# Altium schematic sheet 03 电源部分.SchDoc("03 电源部分")
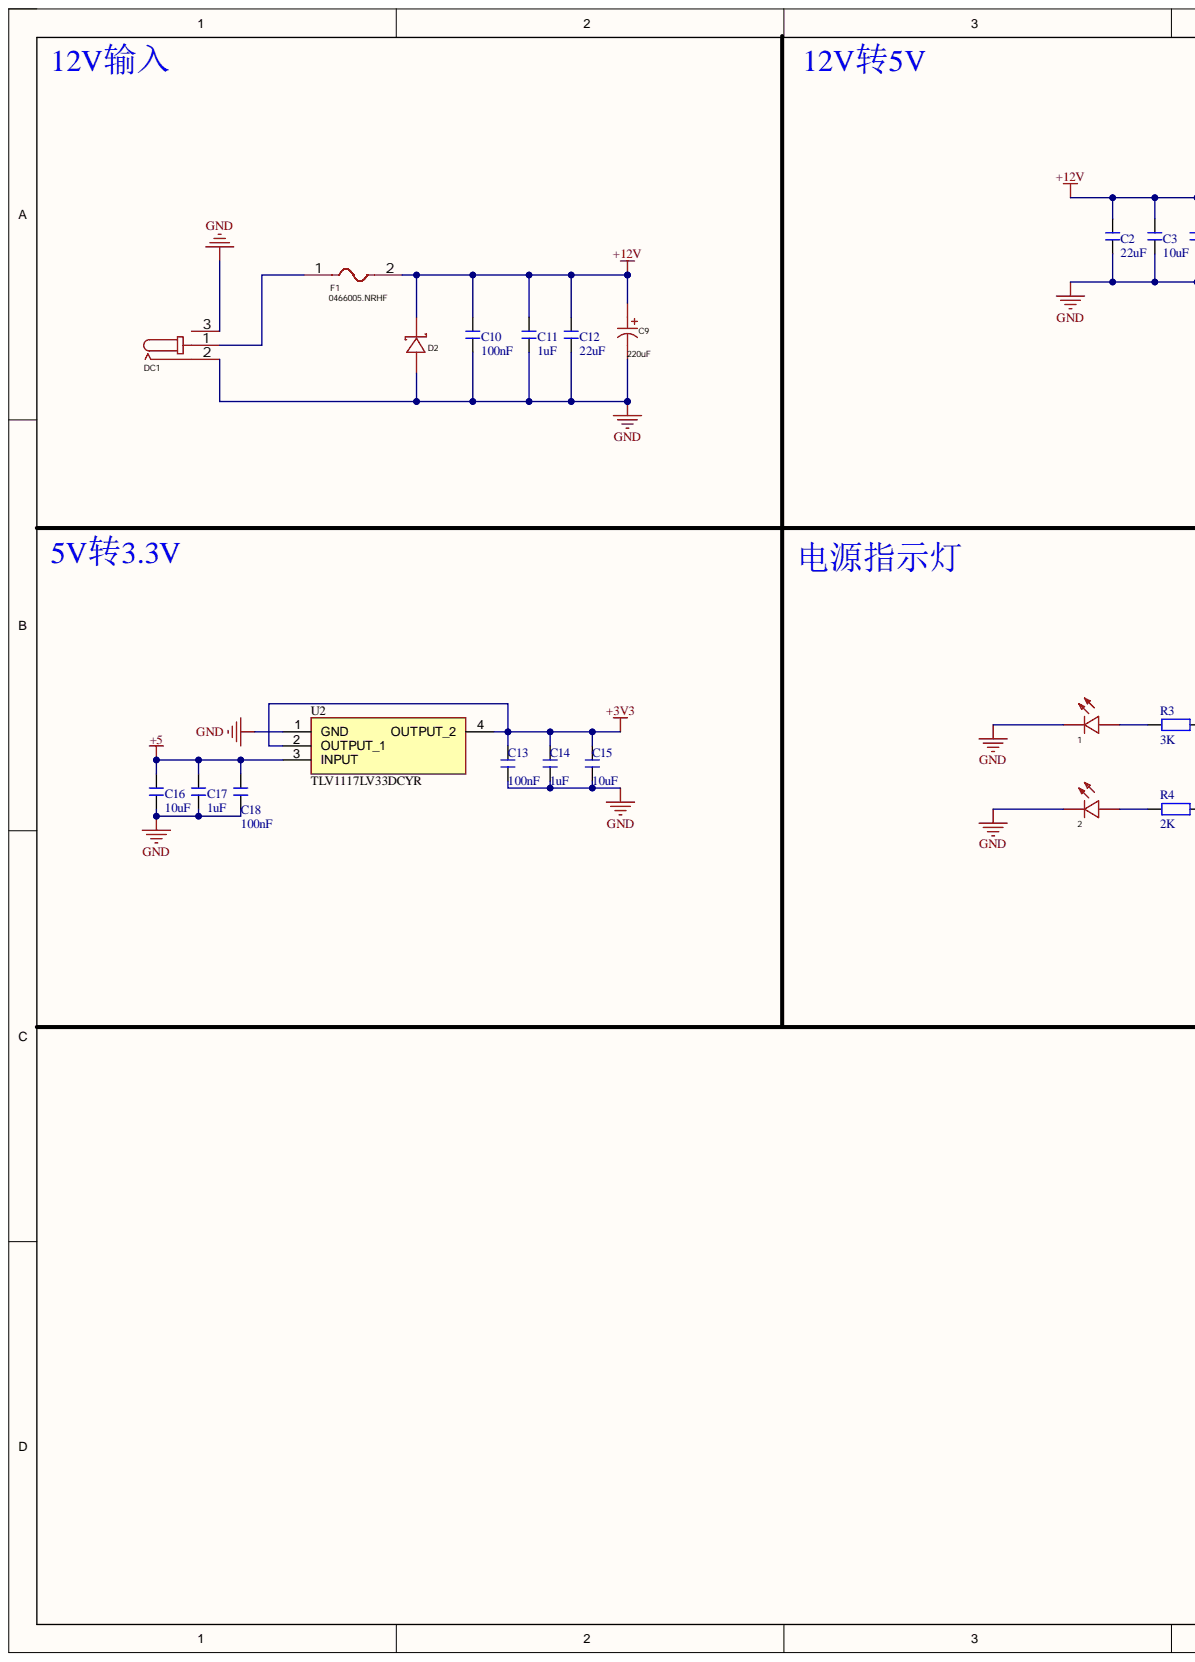
[diagram p0: 03 电源部分.SchDoc, left]
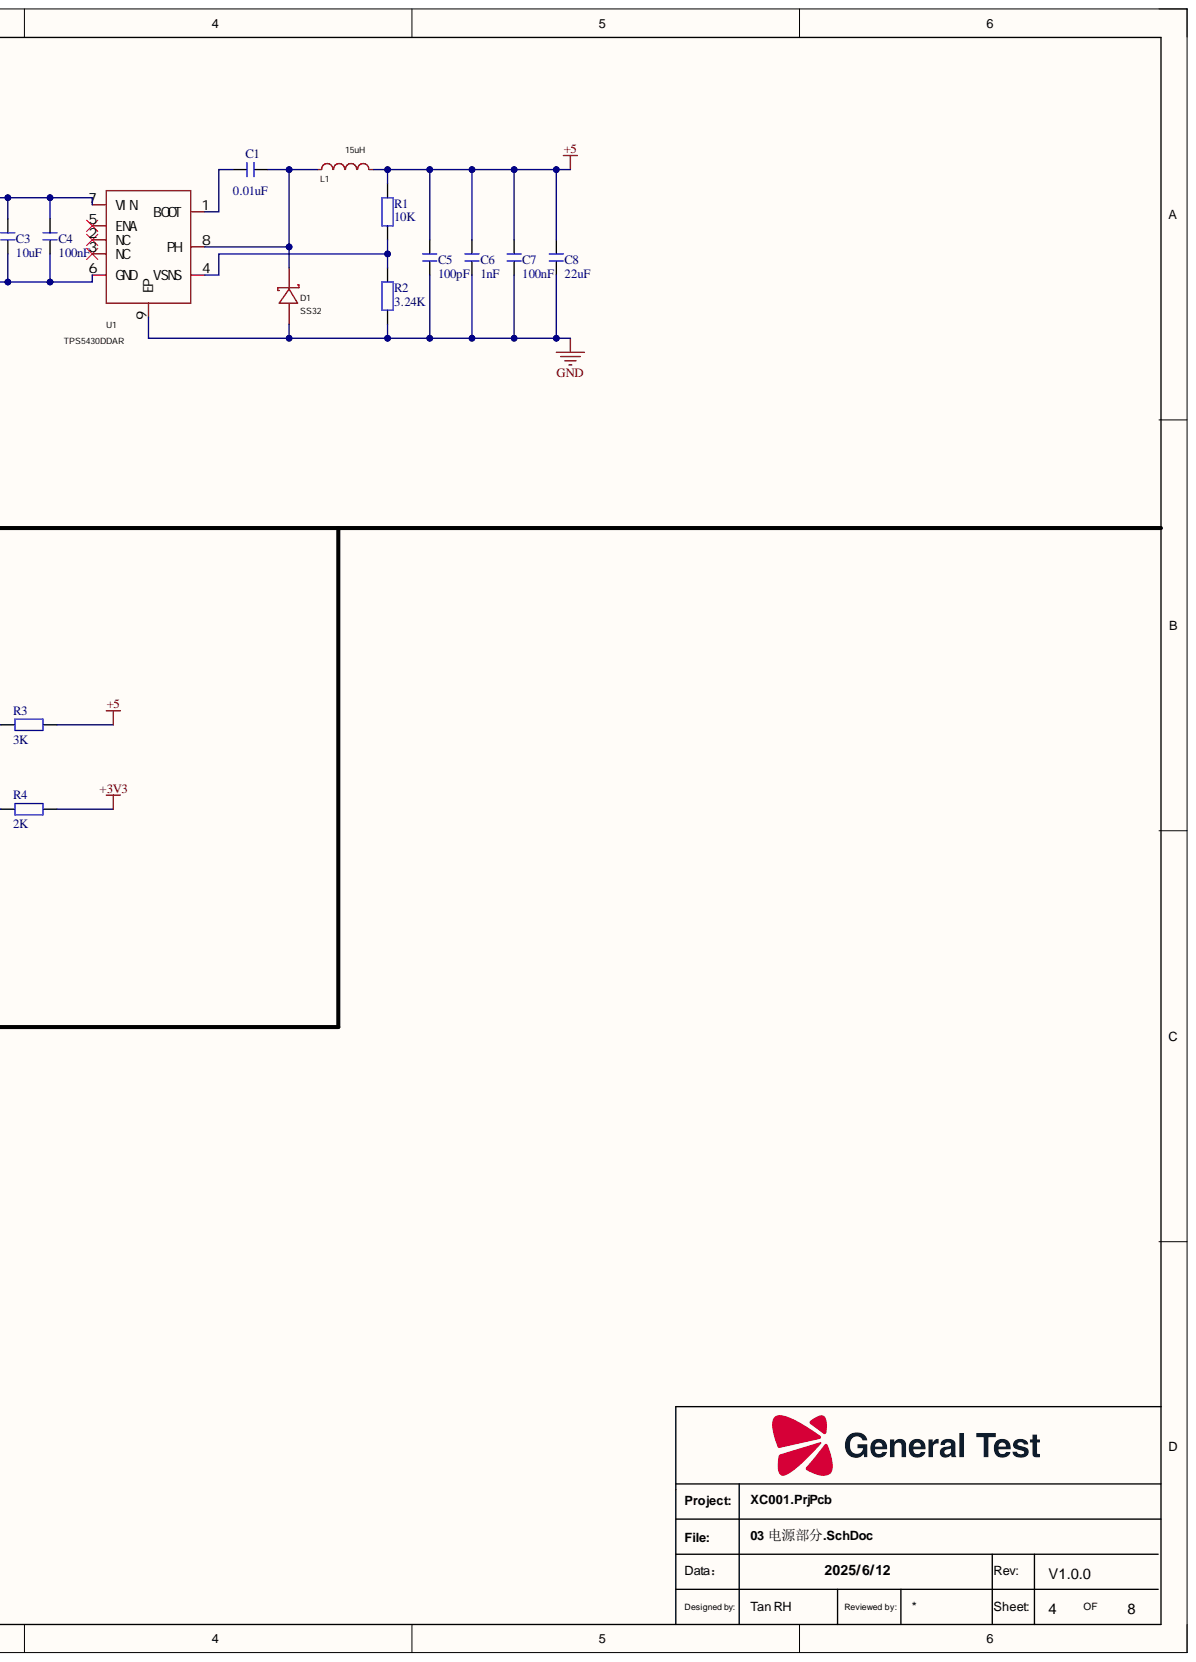
[diagram p1: 03 电源部分.SchDoc, right]

## Parts
1  NCD0603B1  [LED0603-RD]  (value not drawn)
2  NCD0603B1  [LED0603-RD]  (value not drawn)
C1  0.01uF  [C0805_M]
C2  22uF  [C0805_M]
C3  10uF  [C0805_M]
C4  100nF  [C0603_L]
C5  100pF  [C0603_L]
C6  1nF  [C0603_L]
C7  100nF  [C0603_L]
C8  22uF  [C0805_M]
C9  220uF  [CAP-SMD_BD6.3-L6.6-W6.6-LS7.4-FD]
C10  100nF  [C0603_L]
C11  1uF  [C0603_L]
C12  22uF  [C0805_M]
C13  100nF  [C0603_L]
C14  1uF  [C0603_L]
C15  10uF  [C0603_L]
C16  10uF  [C0603_L]
C17  1uF  [C0603_L]
C18  100nF  [C0603_L]
D1  SS32  [SMA_L4.3-W2.6-LS5.2-RD]
D2  SS32  [SMA_L4.3-W2.6-LS5.2-RD]  (value not drawn)
DC1  DC-053D-25A  [DC-IN-TH_DC-053D-25A-1]  (value not drawn)
F1  0466005.NRHF  [F1206]
L1  15uH  [IND-SMD_L7.1-W6.6_SLO0618H]
R1  10K  [R0603_L]
R2  3.24K  [R0603_L]
R3  3K  [R0603_L]
R4  2K  [R0603_L]
U1  TPS5430DDAR  [SOIC127P600X170-9N]
U2  TLV1117LV33DCYR  [SOT230P700X180-4N]

## Nets
+3V3: C13.2 C14.2 C15.2 R4.2 U2.2(OUTPUT_1) U2.4(OUTPUT_2)
+5: C5.2 C6.2 C7.2 C8.2 C16.2 C17.2 C18.2 L1.2 R1.2 R3.2 U2.3(INPUT)
+12V: C2.2 C3.2 C4.2 C9.1 C10.1 C11.1 C12.1 D2.1 F1.2 U1.7(VIN)
GND: 1.1 2.1 C2.1 C3.1 C4.1 C5.1 C6.1 C7.1 C8.1 C9.2 C10.2 C11.2 C12.2 C13.1 C14.1 C15.1 C16.1 C17.1 C18.1 D1.2 D2.2 DC1.2 DC1.3 R2.1 U1.6(GND) U1.9(EP) U2.1(GND)
Net1_2: 1.2 R3.1
Net2_2: 2.2 R4.1
NetC1_1: C1.1 D1.1 L1.1 U1.8(PH)
NetC1_2: C1.2 U1.1(BOOT)
NetDC1_1: DC1.1 F1.1
NetR1_1: R1.1 R2.2 U1.4(VSNS)

Not connected: U1 2(NC) 3(NC) 5(ENA)

## Library data of the parts
1 2 = NCD0603B1: Manufacturer: 国星光电; Add into BOM: yes; Dominant Wavelength: 463nm~475nm; Emitted Color: 蓝色; Forward Current: 10mA; Forward Voltage (VF): 2.6V~3.6V; Lens Color: 无色透明透镜; Luminous Intensity: 80mcd~220mcd; Power Dissipation: 72mW; Symbol: FC-DA1608BK-470H10; Viewing Angle: 130°; Component Kind: Standard; Convert to PCB: yes; Datasheet: https://atta.szlcsc.com/upload/public/pdf/source/20200820/C84266_6A3CDBEFA72C6CAD68EDA53474ADAE6C.pdf; Device: NCD0603B1; JLCPCB Part Class: Extended Part; LCSC Part Name: NCD0603B1; Library Name: Schlib1.SchLib; Manufacturer Part: NCD0603B1; Operating Temperature: -30℃~+85℃; Supplier: LCSC; Supplier Footprint: 0603; Supplier Part: C84266
C1-C8 C10-C12 = C: 贴片电容; BOM名称: 无极性贴片电容; 淘宝ID: 封装库来自淘宝 ID：黄晶宝石; Component Kind: Standard; Library Name: 0.1 - 电阻-电容-电感.SchLib; 唯一出售店铺网址: https://shop463601444.taobao.com; 联系售后，技术支持: https://shop463601444.taobao.com
C9 = RVT1E221M0607: Manufacturer: ROQANG(容强); Add into BOM: yes; L: 7.7mm; Lifetime @ Temp: 2000hrs@105℃; Rated Voltage: 25V; Ripple Current: 150mA@120Hz; Symbol: RVT1E221M0607_C2918361; Tolerance: ±20%; φD: 6.3mm; Component Kind: Standard; Convert to PCB: yes; Datasheet: https://atta.szlcsc.com/upload/public/pdf/source/20211118/497C0614266278696CA52BDF245B991F.pdf; Device: RVT1E221M0607; Equivalent Series Resistance(ESR): -; JLCPCB Part Class: Extended Part; LCSC Part Name: 220uF ±20% 25V; Library Name: RK3562 Pcb.SchLib; Manufacturer Part: RVT1E221M0607; Operating Temperature: -40℃~+105℃; PCB3D: CAP-SMD_BD6.3-L6.6-W6.6-LS7.4-FD; Supplier: LCSC; Supplier Footprint: SMD,D6.3xL7.7mm; Supplier Part: C2918361; Value: 220uF
C13 C18 = C: 贴片电容; BOM名称: 无极性贴片电容; LibRef: GRM155R71C104JA88D; 淘宝ID: 封装库来自淘宝 ID：黄晶宝石; Component Kind: Standard; Library Name: 0.1 - 电阻-电容-电感.SchLib; 唯一出售店铺网址: https://shop463601444.taobao.com; 联系售后，技术支持: https://shop463601444.taobao.com
C14 C17 = C: 贴片电容; BOM名称: 无极性贴片电容; LibRef: GRM155R61H105KE05D; 淘宝ID: 封装库来自淘宝 ID：黄晶宝石; Component Kind: Standard; Library Name: 0.1 - 电阻-电容-电感.SchLib; 唯一出售店铺网址: https://shop463601444.taobao.com; 联系售后，技术支持: https://shop463601444.taobao.com
C15 C16 = C: 贴片电容; BOM名称: 无极性贴片电容; LibRef: GRM155C80J106ME18D; 淘宝ID: 封装库来自淘宝 ID：黄晶宝石; Component Kind: Standard; Library Name: 0.1 - 电阻-电容-电感.SchLib; 唯一出售店铺网址: https://shop463601444.taobao.com; 联系售后，技术支持: https://shop463601444.taobao.com
D1 D2 = SS32_C34897: Manufacturer: MDD(辰达半导体); Add into BOM: yes; Diode Configuration: 独立式; Forward Voltage (Vf@If): 550mV@3A; Rectified Current: 3A; Reverse Leakage Current (Ir): 500uA@20V; Reverse Voltage (Vr): 20V; Component Kind: Standard; Convert to PCB: yes; Datasheet: https://atta.szlcsc.com/upload/public/pdf/source/20240710/2118BB86FDDB2E0B294389AC1F3F65C8.pdf; Device: SS32_C34897; JLCPCB Part Class: Extended Part; LCSC Part Name: 电压:20V 电流:3A; Manufacturer Part: SS32; Supplier: LCSC; Supplier Footprint: SMA(DO-214AC); Supplier Part: C34897; Symbol: SS32_C34897
  D1: Library Name: Schlib1.SchLib
  D2: Library Name: PowerDetector.SchLib
DC1 = DC-053D-25A: Manufacturer: 韩国韩荣; Add into BOM: yes; Connector Type: DC电源插座; Current Rating (Max): 10A; Inside Contact Diameter: 2.5mm; Outside Contact Diameter: 6.5mm; Voltage Rating (Max): 16V; Component Kind: Standard; Convert to PCB: yes; Datasheet: https://atta.szlcsc.com/upload/public/pdf/source/20211013/02DB261FD23242C4DED947007A9F6266.pdf; Device: DC-053D-25A; JLCPCB Part Class: Extended Part; LCSC Part Name: DC电源插座 内径:2.5mm 外径:6.5mm; Library Name: RK3562 Pcb.SchLib; Manufacturer Part: DC-053D-25A; Mounting Style: 弯插; Operating Temperature Range: -20℃~+70℃; PCB3D: DC-IN-TH_DC-053D-25A-1; Supplier: LCSC; Supplier Footprint: 插件; Supplier Part: C2686352; Symbol: DC-053D-25A
F1 = 0466005.NRHF: Manufacturer: Littelfuse(美国力特); Add into BOM: yes; Breaking Capacity: 50A; Current Rating: 5A; Type: 贴片式保险丝; Voltage Rating  (AC): 32V; Component Kind: Standard; Convert to PCB: yes; Datasheet: https://atta.szlcsc.com/upload/public/pdf/source/20171201/C151136_15121178482301156393.pdf; Device: 0466005.NRHF; JLCPCB Part Class: Extended Part; LCSC Part Name: 32V贴片式保险丝; Library Name: RK3562 Pcb.SchLib; Manufacturer Part: 0466005.NRHF; Operating Temperature: -55℃~+90℃; PCB3D: F1206_L3.2-W1.7-H0.6; Supplier: LCSC; Supplier Footprint: 1206; Supplier Part: C57525; Symbol: 0466005.NRHF; Voltage Rating (DC): 32V
L1 = SLO0630H150MTT: Manufacturer: Sunltech(韩国顺磁); Add into BOM: yes; DC Resistance (DCR): 115mΩ; Rated Current: 3A; Tolerance: ±20%; Component Kind: Standard; Convert to PCB: yes; Datasheet: https://atta.szlcsc.com/upload/public/pdf/source/20190925/C207844_D5F1113EB7E791F3C24FBC0C5D2BA774.pdf; Device: SLO0630H150MTT; JLCPCB Part Class: Extended Part; LCSC Part Name: 15uH ±20%; Library Name: Schlib1.SchLib; Manufacturer Part: SLO0630H150MTT; Supplier: LCSC; Supplier Footprint: SMD; Supplier Part: C182182; Symbol: SLO0630H150MTT; Value: 15uH
R1-R4 = R: 贴片电阻; 封装库来自淘宝卖家 ID：黄晶宝石: 其他店铺购入均为盗版，不享受售后技术支持服务，请立即申请退款; 版权归属: 来自淘宝 ID：黄晶宝石; BOM名称: 贴片电阻; Component Kind: Standard; Library Name: 0.1 - 电阻-电容-电感.SchLib; 联系售后: https://item.taobao.com/item.htm?id=566775463719
U1 = TPS5430DDAR: Manufacturer: TI(德州仪器); Add into BOM: yes; Function: 降压型; Input Voltage: 5.5V~36V; Number of Outputs: 1; Output Current (Max): 3A; Output Type: 可调; Output Voltage: 1.221V~32.04V; Switch tube (built-in/external): 内置; Switching Frequency: 500kHz; Synchronous Rectification: 否; Topology: 降压式; Component Kind: Standard; Convert to PCB: yes; Datasheet: https://www.ti.com/cn/lit/gpn/tps5430; Device: TPS5430DDAR; JLCPCB Part Class: Extended Part; LCSC Part Name: 降压 3A 36V; Library Name: Stm32h743 Pcb.SchLib; Manufacturer Part: TPS5430DDAR; Operating Temperature: -40℃~+125℃@(TJ); Supplier: LCSC; Supplier Footprint: SOIC-8-EP; Supplier Part: C9864; Symbol: TPS5430DDAR
U2 = TLV1117LV33DCYR: Integrated Circuit; Manufacturer_Name: Texas Instruments; Arrow Part Number: TLV1117LV33DCYR; Arrow Price/Stock: https://www.arrow.com/en/products/tlv1117lv33dcyr/texas-instruments?utm_currency=USD&region=nac; Component Kind: Standard; Datasheet Link: http://www.ti.com/lit/gpn/tlv1117lv; Height: 1.8mm; Manufacturer_Part_Number: TLV1117LV33DCYR; Mouser Part Number: 595-TLV1117LV33DCYR; Mouser Price/Stock: https://www.mouser.co.uk/ProductDetail/Texas-Instruments/TLV1117LV33DCYR?qs=tEz3BkPb1rwzXMb8FiWhow%3D%3D
